# Altium schematic sheet 2_A9912FH-PCB1-BRIDGE _SR_POWER_V1.SchDoc("2_A9912FH-PCB1-BRIDGE _SR_POWER_V1")
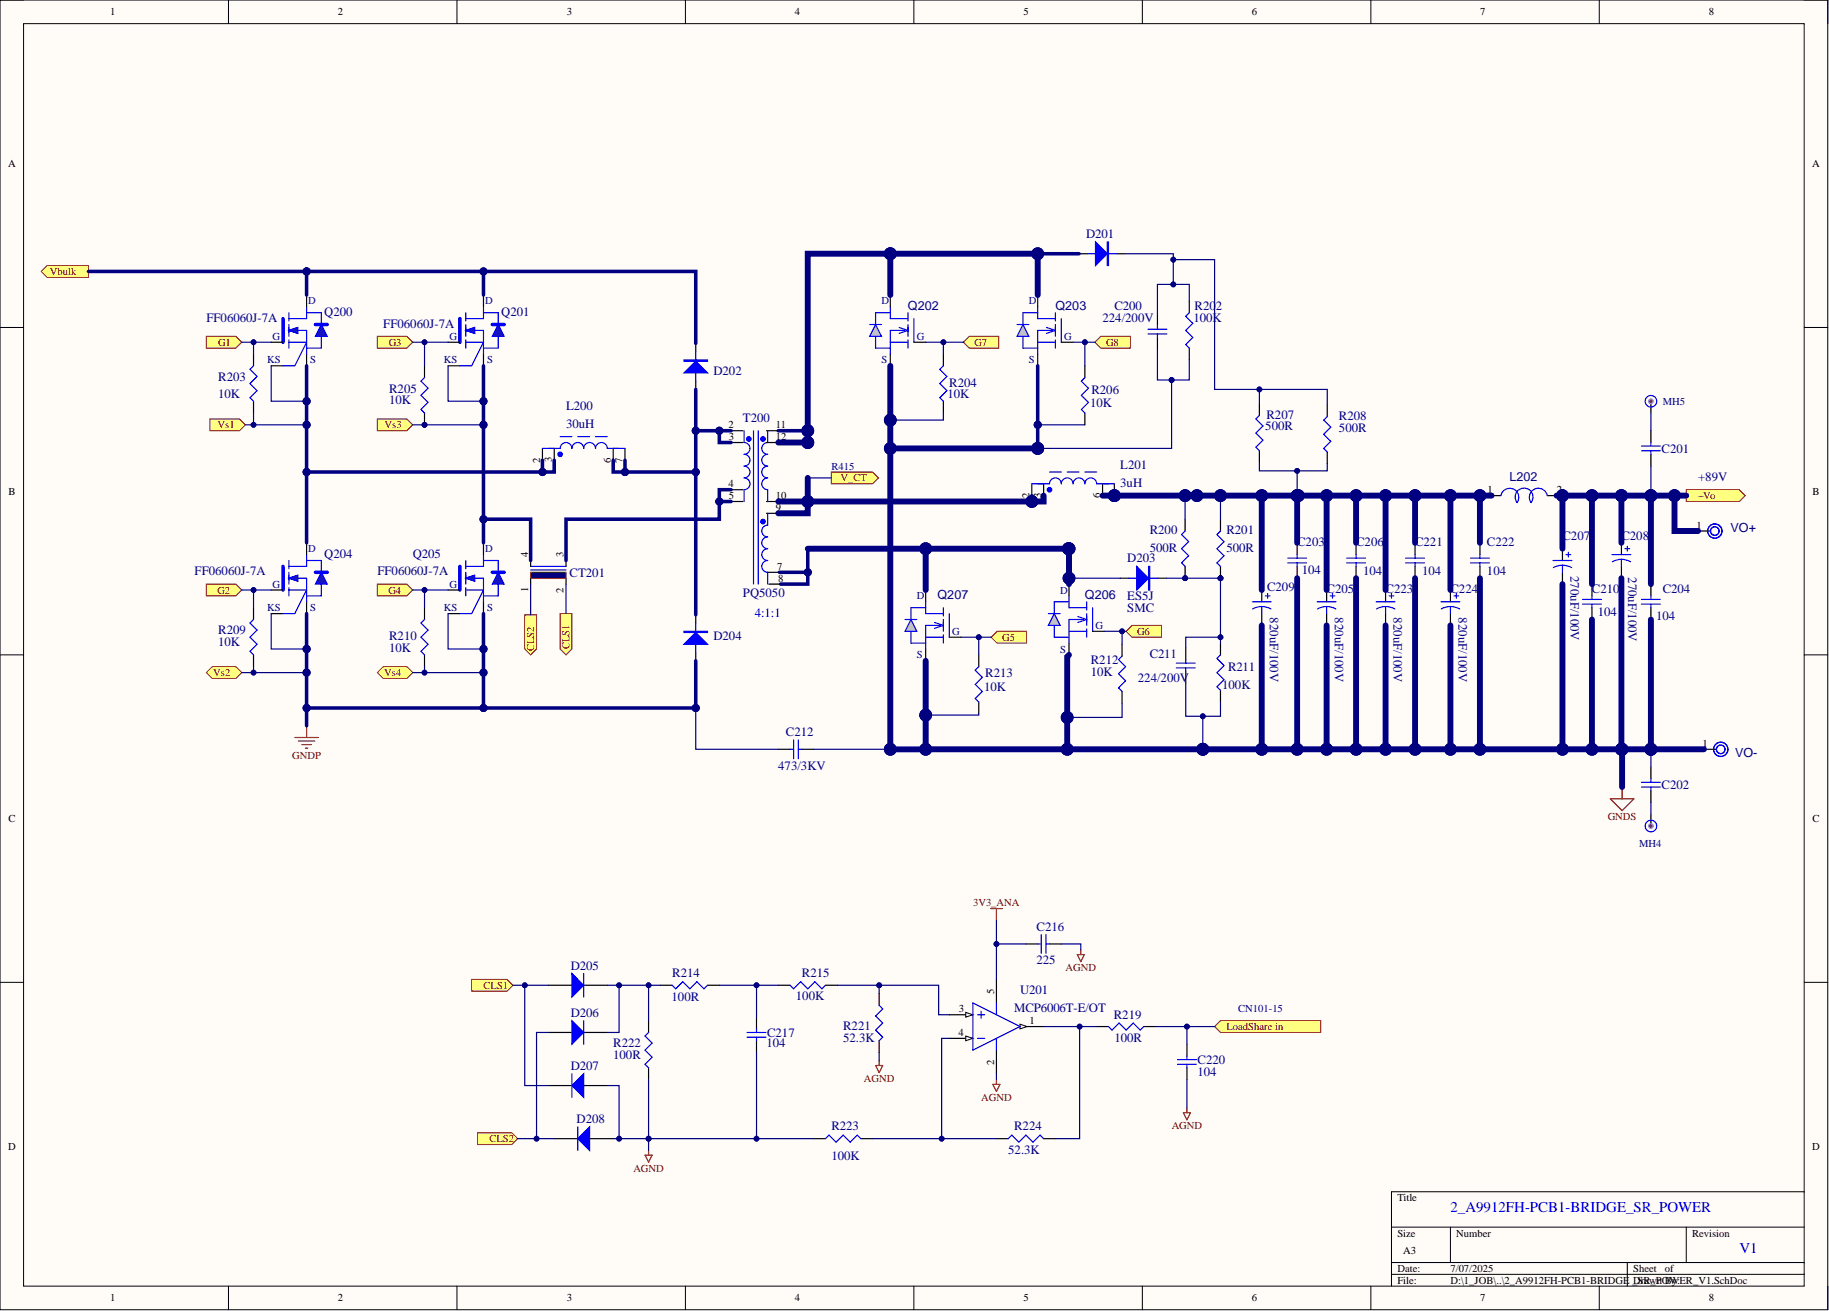
[diagram p0: 2_A9912FH-PCB1-BRIDGE _SR_POWER_V1.SchDoc]

## Parts
C200  value 224/200V  [1210 (3225 Metric)]  (hidden comment: CAP CER 110nF 25V 20% X5R 1210)
C201  (no value)  [CS-1206S]
C202  (no value)  [CS-1206S]
C203  value 104  [1210 (3225 Metric)]  (hidden comment: CAP CER 22UF 25V 20% X5R 1210)
C204  value 104  [1210 (3225 Metric)]  (hidden comment: CAP CER 22UF 25V 20% X5R 1210)
C205  820uF/100V
C206  value 104  [1210 (3225 Metric)]  (hidden comment: CAP CER 22UF 25V 20% X5R 1210)
C207  270uF/100V
C208  270uF/100V
C209  820uF/100V
C210  value 104  [1210 (3225 Metric)]  (hidden comment: CAP CER 22UF 25V 20% X5R 1210)
C211  value 224/200V  [1210 (3225 Metric)]  (hidden comment: CAP CER 110nF 25V 20% X5R 1210)
C212  473/3KV  [CY-15X5-P10]
C216  225  [CS-0805]
C217  104  [CS-0805]
C220  value 104  [CS-0805]  (hidden comment: Cap Semi)
C221  value 104  [1210 (3225 Metric)]  (hidden comment: CAP CER 22UF 25V 20% X5R 1210)
C222  value 104  [1210 (3225 Metric)]  (hidden comment: CAP CER 22UF 25V 20% X5R 1210)
C223  820uF/100V
C224  820uF/100V
CT201  (no value)  [LF-TR02689DIFF]
D201  ES5J  [DS-SMC]  (value not drawn)
D202  MUR160E3/5BT  [DS-SMC]  (value not drawn)
D203  ES5J  parater 1: SMC  [DS-SMC]
D204  MUR160E3/5BT  [DS-SMC]  (value not drawn)
D205  MBR0540T1G  [SOD-123]  (value not drawn)
D206  MBR0540T1G  [SOD-123]  (value not drawn)
D207  MBR0540T1G  [SOD-123]  (value not drawn)
D208  MBR0540T1G  [SOD-123]  (value not drawn)
L200  30uH  [T-PQ3225H-8]
L201  3uH  [T-PQ3225H-8]
L202  4uH  [COL-21R-P16]  (value not drawn)
MH4  SCREW-HOLE  [MH8VIA-7_5R-D3_5]  (value not drawn)
MH5  SCREW-HOLE  [MH8VIA-7_5R-D3_5]  (value not drawn)
Q200  FF06060J-7A  [MOS-TO263-7L-GSD]
Q201  FF06060J-7A  [MOS-TO263-7L-GSD]
Q202  IRFP4368PbF  [MOS-TO263-GSD]  (value not drawn)
Q203  IRFP4368PbF  [MOS-TO263-GSD]  (value not drawn)
Q204  FF06060J-7A  [MOS-TO263-7L-GSD]
Q205  FF06060J-7A  [MOS-TO263-7L-GSD]
Q206  IRFP4368PbF  [MOS-TO263-GSD]  (value not drawn)
Q207  IRFP4368PbF  [MOS-TO263-GSD]  (value not drawn)
R200  500R  [RS-1206S]
R201  500R  [RS-1206S]
R202  100K  [RS-1206S]
R203  10K  [RS-0805]
R204  10K  [RS-0805]
R205  10K  [RS-0805]
R206  10K  [RS-0805]
R207  500R  [RS-1206S]
R208  500R  [RS-1206S]
R209  10K  [RS-0805]
R210  10K  [RS-0805]
R211  100K  [RS-1206S]
R212  10K  [RS-0805]
R213  10K  [RS-0805]
R214  100R  [RS-0805]
R215  100K  [RS-0805]
R219  100R  [RS-0805]
R221  52.3K  [RS-0805]
R222  100R  [RS-0805]
R223  100K  [RS-0805]
R224  52.3K  [RS-0805]
T200  PQ5050  [T-PQ5050-12]
U201  MCP6006T-E/OT  [IC-SOT23-5]
VO+  TP_30_mils_74  [AWGH-DC-18~22-D2_1]  (value not drawn)
VO-  TP_30_mils_74  [AWGH-DC-18~22-D2_1]  (value not drawn)

## Ports
+Vo, CLS1, CLS2, G1, G2, G3, G4, G5, G6, G7, G8, LoadShare in, V_CT, Vbulk, Vs1, Vs2, Vs3, Vs4

## Nets
3V3_ANA: C216.2 U201.5
AGND: C216.1 C217.2 C220.2 D207.A D208.A R221.2 R222.1 R223.1 U201.2
GNDS: C200.1 C202.2 C203.1 C204.1 C205.2 C206.1 C207.2 C208.2 C209.2 C210.1 C211.1 C212.2 C221.1 C222.1 C223.2 C224.2 Q202.S Q203.S Q206.S Q207.S R202.1 R204.1 R206.1 R211.1 R212.1 R213.1 VO-.1
+Vo: C201.1 C204.2 C207.1 C208.1 C210.2 L202.2 VO+.1 ; port +Vo
CLS1: CT201.2 D205.A D207.K ; port CLS1
CLS2: CT201.1 D206.A D208.K ; port CLS2
G1: Q200.G R203.2 ; port G1
G2: Q204.G R209.2 ; port G2
G3: Q201.G R205.2 ; port G3
G4: Q205.G R210.2 ; port G4
G5: Q207.G R213.2 ; port G5
G6: Q206.G R212.2 ; port G6
G7: Q202.G R204.2 ; port G7
G8: Q203.G R206.2 ; port G8
GNDP: C212.1 D204.A Q204.KS Q204.S Q205.KS Q205.S R209.1 R210.1 ; port Vs2, port Vs4
LoadShare in: C220.1 R219.1 ; port LoadShare in
V_CT: L201.2 L201.3 T200.9 T200.10 ; port V_CT
Vbulk: D202.K Q200.D Q201.D ; port Vbulk
Vs1: L200.2 L200.3 Q200.KS Q200.S Q204.D R203.1 ; port Vs1
Vs3: CT201.4 Q201.KS Q201.S Q205.D R205.1 ; port Vs3
NetC200_2: C200.2 D201.K R202.2 R207.2 R208.2
NetC201_2: C201.2 MH5.1
NetC202_1: C202.1 MH4.1
NetC203_2: C203.2 C205.1 C206.2 C209.1 C221.2 C222.2 C223.1 C224.1 L201.6 L201.7 L202.1 R200.2 R201.2 R207.1 R208.1
NetC211_2: C211.2 D203.K R200.1 R201.1 R211.2
NetC217_1: C217.1 R214.1 R215.2
NetCT201_3: CT201.3 T200.4 T200.5
NetD201_A: D201.A Q202.D Q203.D T200.11 T200.12
NetD202_A: D202.A D204.K L200.6 L200.7 T200.2 T200.3
NetD203_A: D203.A Q206.D Q207.D T200.7 T200.8
NetD205_K: D205.K D206.K R214.2 R222.2
NetR215_1: R215.1 R221.1 U201.3
NetR219_2: R219.2 R224.2 U201.1
NetR223_2: R223.2 R224.1 U201.4

## Library data of the parts
C200 C203 C204 C206 C210 C211 C221 C222 = Cap Semi: Capacitor (Semiconductor SIM Model); Disti PartNumber: 587-2086-1-ND; PartNumber: TMK325BJ226MM-T; Component Kind: Standard; Library Name: Miscellaneous Devices.IntLib; PackageDescription: Chip Capacitor; PackageReference: C1210; Signal Integrity: Cap Semi; Simulation: CAP
  C200 C211: Description/Value: CAP CER 110nF 25V 20% X5R 1210
  C203 C204 C206 C210 C221 C222: Description/Value: CAP CER 22UF 25V 20% X5R 1210
C201 C202 = Cap Semi: Capacitor (Semiconductor SIM Model); Value: 473/3KV; Component Kind: Standard; LatestRevisionDate: 29-May-2009; LatestRevisionNote: IPC-7351 Footprint Added.; Library Name: Miscellaneous Devices.IntLib; PackageDescription: Chip Capacitor; PackageReference: CAP_TH_15MM; Published: 8-Jun-2000; Signal Integrity: Cap Semi; Simulation: CAP; Publisher: Altium Limited
C205 C207-C209 C223 C224 = Cap Pol1: Polarized Capacitor (Radial); Disti PartNumber: 1189-1592-1-ND; PartNumber: 25ZLJ1500MGA12.5X20; Description/Value: CAP ALUM 1500UF 25V 20% RADIAL; Component Kind: Standard; Library Name: Miscellaneous Devices.IntLib; PackageDescription: Polarized Capacitor (Radial); 2 Leads; PackageReference: CAP 5XR10 13.5mm Dia; Signal Integrity: Cap Pol; Simulation: CAP
  C205 C209 C223 C224: Value: 820uF/100V
  C207 C208: Value: 270uF/100V
C212 C216 C217 C220 = Cap Semi: Capacitor (Semiconductor SIM Model); Component Kind: Standard; LatestRevisionDate: 29-May-2009; LatestRevisionNote: IPC-7351 Footprint Added.; Library Name: Miscellaneous Devices.IntLib; PackageDescription: Chip Capacitor; Published: 8-Jun-2000; Signal Integrity: Cap Semi; Simulation: CAP; Publisher: Altium Limited
  C212: PackageReference: CAP_TH_15MM; Value: 473/3KV
  C216: PackageReference: CAP0805; Value: 225
  C217: PackageReference: CAP0805; Value: 104
  C220: PackageReference: CAP0805
CT201 = T-AS101-2+FL2: Component Kind: Standard; Library Name: COIL-SCH.SCHLIB
D201 D202 D204 = DIODE_2: Diode; parater 1: SMC; Component Kind: Standard; Library Name: A9911FH_V1.SCHLIB
D203 = DIODE_2: Diode; Component Kind: Standard; Library Name: A9911FH_V1.SCHLIB
D205-D208 = Diode 1N4001: 1 Amp General Purpose Rectifier; Disti PartNumber: MBR0540T1GOSCT-ND; Description/Value: DIODE SCHOTTKY 40V 0.5A SOD123; Component Kind: Standard; Library Name: Miscellaneous Devices.IntLib; PackageDescription: Name	Type	Description	Vault	Item Revision; PackageReference: SOD_123; PartNumber: MBR0540T1G; Signal Integrity: 1N4001; Simulation: 1N4001
L200 L201 = L301: Component Kind: Standard; Library Name: A9911FH_V1_1.SCHLIB
L202 = 09A0651180000_KS065125A: Choke Differential 100uH; Value: 2.6mH; Component Kind: Standard; Library Name: A9911FH_V1.SCHLIB
Q200 Q201 Q204 Q205 = MOS-N-TO263-7L: parameter 1: TO-263-7L; Component Kind: Standard; Library Name: MOS&TRANSISTOR-SCH.SchLib
Q202 Q203 Q206 Q207 = MOSFET TO220: MOS 150V/2.4mohm PQFN5x6; Component Kind: Standard; Library Name: A9911FH_V1.SCHLIB; Package: TO-263-2L
R200-R215 R219 R221-R224 = Res3: Resistor; Component Kind: Standard; LatestRevisionDate: 29-May-2009; LatestRevisionNote: IPC-7351 Footprint Added.; Library Name: Miscellaneous Devices.IntLib; PackageDescription: Chip Resistor; Published: 8-Jun-2000; Publisher: Altium Limited
  R200 R207: PackageReference: RES1210; Value: 500R
  R201 R208: PackageReference: RES1216; Value: 500R
  R202 R211 R215 R223: PackageReference: RES0805; Signal Integrity: Res; Simulation: RESISTOR; Value: 100K
  R203-R206 R209 R210 R212 R213: PackageReference: RES0805; Signal Integrity: Res; Simulation: RESISTOR; Value: 10K
  R214 R219 R222: PackageReference: RES0805; Signal Integrity: Res; Simulation: RESISTOR; Value: 100R
  R221 R224: PackageReference: RES0805; Signal Integrity: Res; Simulation: RESISTOR; Value: 52.3K
T200 = 330W LLC TR: Component Kind: Standard; Library Name: A9911FH_V1_1.SCHLIB
U201 = MCP6001RT-E/OT: 1 MHz, Low-Power Operational Amplifier, 5-Pin SOT-23, Extended Temperature, Tape and Reel; Code_IPC: SOT23-5; Component Kind: Standard; ComponentLink1Description: Datasheet; ComponentLink1URL: http://ww1.microchip.com/downloads/en/DeviceDoc/21733j.pdf; ComponentLink2Description: Packaging Specification; ComponentLink2URL: http://www.microchip.com/stellent/groups/techpub_sg/documents/packagingspec/en012702.pdf; ComponentLink3Description: Microchip.com Home; ComponentLink3URL: http://www.microchip.com/; DatasheetVersion: revJ, Nov-2009; LatestRevisionDate: 02-Dec-2009; LatestRevisionNote: Updated to datasheet revision J, Nov-2009; Library Name: Microchip Linear Devices.IntLib; PackageDescription: 5-Lead Plastic Small Outline Transistor (OT) [SOT-23]; PackageReference: SOT-23-OT5; PackageVersion: revBB, Aug-2009; Published: 29-Jan-2009; Simulation: MCP6001; Supplier 1: Digi-Key; Supplier 2: Digi-Key; Supplier 3: Digi-Key; Supplier Part Number 1: MCP6001RT-E/OTTR-ND; Supplier Part Number 2: MCP6001RT-E/OTCT-ND; Supplier Part Number 3: MCP6001RT-E/OTDKR-ND; Publisher: Altium Limited
VO+ VO- = TP_30_mils: Component Kind: Standard; Description: TP_30_mils_74; Library Name: TQFPComp.SCHLIB
MH4 MH5 = SCREW-HOLE: Component Kind: Standard; Library Name: OTHER-SCH.SCHLIB
